# Altium schematic sheet PCM1792A_MainSche.SchDoc
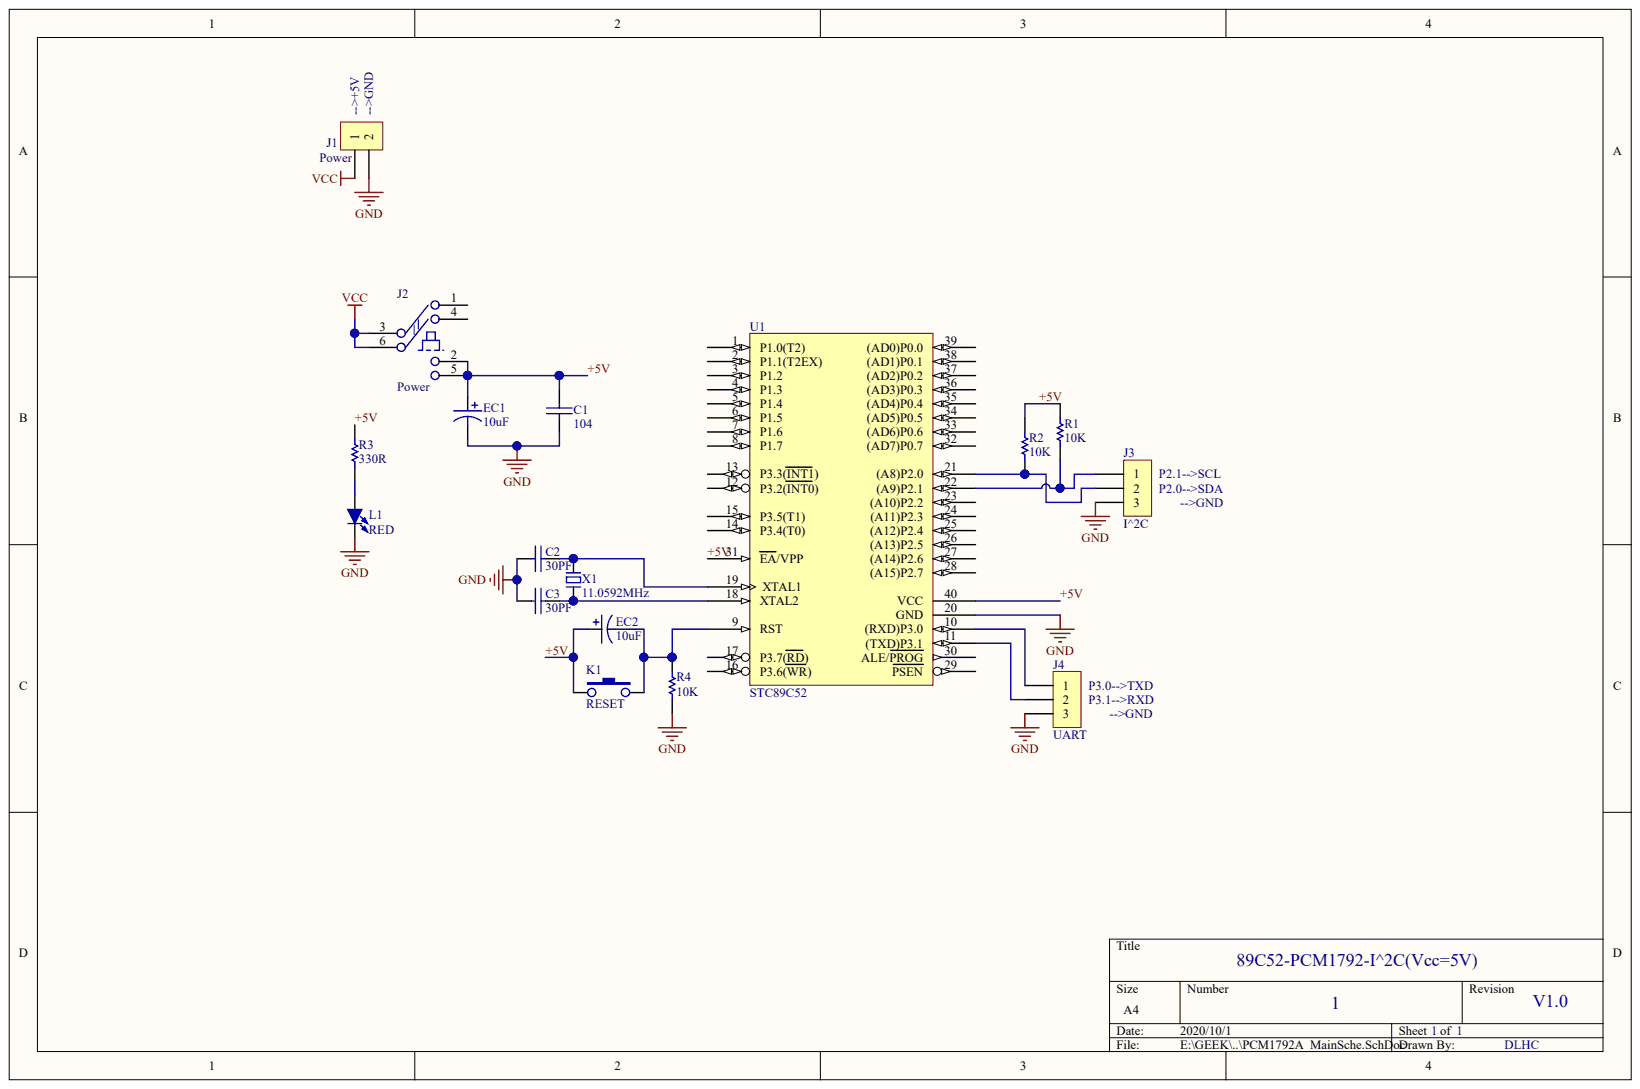
[diagram p0: PCM1792A_MainSche.SchDoc]

## Parts
C1  104  [0201C]
C2  30PF  [0201C]
C3  30PF  [0201C]
EC1  10uF  [DIP-EC1.5X4X8]
EC2  10uF  [DIP-EC1.5X4X8]
J1  Power  [Pin HDR1X2/2.54mm-S]
J2  Power  [KFT DIP-8X8]
J3  I^2C  [Pin HDR1X3/2.54mm-S]
J4  UART  [Pin HDR1X3/2.54mm-S]
K1  RESET  [DIP-3X6X4.3]
L1  RED  [LED_A1]
R1  10K  [0201R]
R2  10K  [0201R]
R3  330R  [0201R]
R4  10K  [0201R]
U1  STC89C52  [DIP40]
X1  11.0592MHz  [OSC HC-49S]

## Nets
GND: C1.1 C2.1 C3.1 EC1.2 J1.2 J3.3 J4.3 L1.2 R4.1 U1.20(GND)
VCC: J1.1 J2.3 J2.6
+5V: C1.2 EC1.1 EC2.1 J2.2 J2.5 K1.1 R1.2 R2.2 R3.2 U1.31(EA/VPP) U1.40(VCC)
NetC2_2: C2.2 U1.19(XTAL1) X1.2
NetC3_2: C3.2 U1.18(XTAL2) X1.1
NetEC2_2: EC2.2 K1.3 R4.2 U1.9(RST)
NetJ3_1: J3.1 R1.1 U1.22((A9)P2.1)
NetJ3_2: J3.2 R2.1 U1.21((A8)P2.0)
NetJ4_1: J4.1 U1.10((RXD)P3.0)
NetJ4_2: J4.2 U1.11((TXD)P3.1)
NetL1_1: L1.1 R3.1

Not connected: J2 1 4; U1 1(P1.0(T2)) 2(P1.1(T2EX)) 3(P1.2) 4(P1.3) 5(P1.4) 6(P1.5) 7(P1.6) 8(P1.7) 12(P3.2(INT0)) 13(P3.3(INT1)) 14(P3.4(T0)) 15(P3.5(T1)) 16(P3.6(WR)) 17(P3.7(RD)) 23((A10)P2.2) 24((A11)P2.3) 25((A12)P2.4) 26((A13)P2.5) 27((A14)P2.6) 28((A15)P2.7) 29(PSEN) 30(ALE/PROG) 32((AD7)P0.7) 33((AD6)P0.6) 34((AD5)P0.5) 35((AD4)P0.4) 36((AD3)P0.3) 37((AD2)P0.2) 38((AD1)P0.1) 39((AD0)P0.0)

## Library data of the parts
C1-C3 = CAP: 无极性电容; Component Kind: Standard; Library Name: 电容.IntLib
EC1 EC2 = DIP_ECAP: 直插电解电容; Component Kind: Standard; Library Name: 电容.IntLib
J1 = Pin HDR1X2: Component Kind: Standard; Library Name: 排针.IntLib
J2 = KEY-SL: 自锁开关; 淘宝ID: 小糖豆的小窝窝; BOM名称: 自锁开关; Component Kind: Standard; Library Name: 03 - 按键-开关-晶振-蜂鸣器.SchLib; 下单链接: https://item.taobao.com/item.htm?ft=t&id=613637044352
J3 J4 = Pin HDR1X3: Component Kind: Standard; Library Name: 排针.IntLib
K1 = KEY: Component Kind: Standard; Library Name: 轻触开关.IntLib
L1 = LED: 发光二极管; 淘宝ID: 小糖豆的小窝窝; BOM名称: 发光二极管; Component Kind: Standard; Library Name: 02 - 二极管(LED)-三极管-整流桥.SchLib; 下单链接: https://item.taobao.com/item.htm?ft=t&id=613637044352
R1-R4 = RES: Component Kind: Standard; Library Name: 电阻.IntLib
X1 = XTAL-2P: 2脚无源脚晶振; Component Kind: Standard; Library Name: 晶振.IntLib
U1 = STC89C52: Component Kind: Standard; Library Name: STC系列芯片.IntLib
